# Altium schematic sheet MCU Main.SchDoc
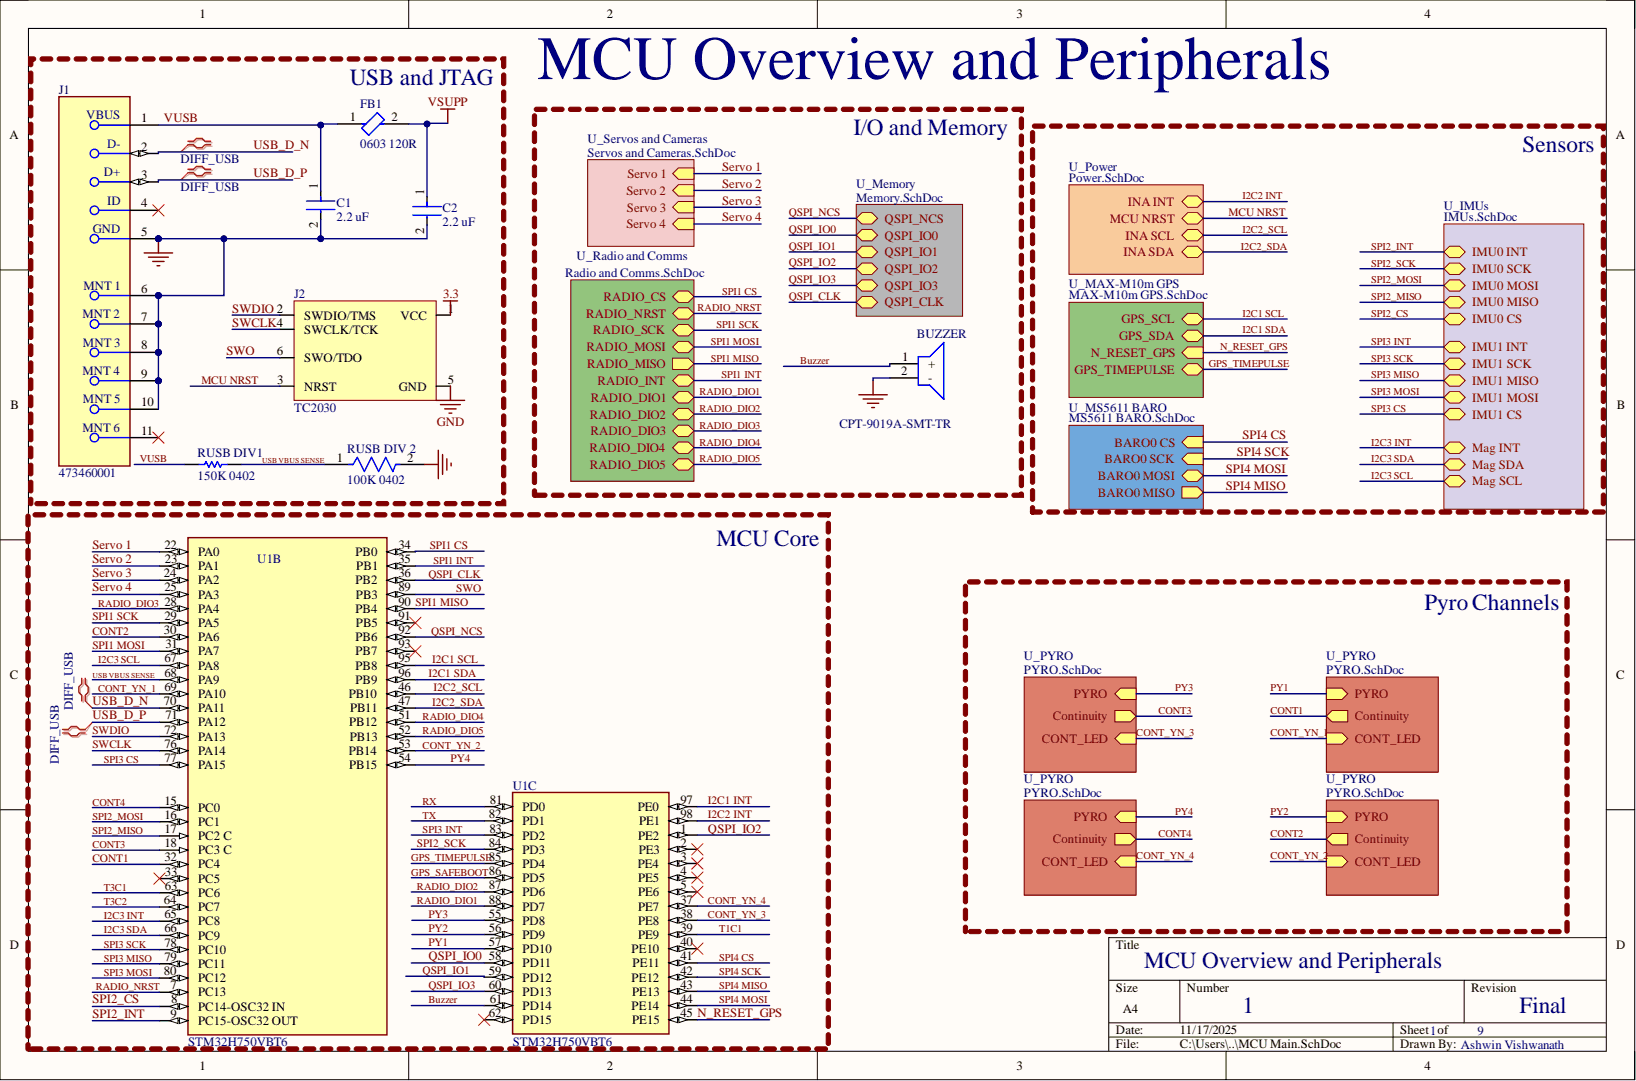
[diagram p0: MCU Main.SchDoc]

## Parts
BUZZER  CPT-9019A-SMT-TR  [CPT9019ASMTTR]
C1  2.2 uF  [FP-CL10-IPC_A]
C2  2.2 uF  [FP-CL10-IPC_A]
FB1  0603 120R  Case Code (Imperial): 0603  Impedance: 120R  [FP-MPZ1608-0_6-IPC_A]
J1  473460001  [FP-473460001-MFG]
J2  TC2030  [TC2030-FP]  (no BOM)
RUSB_DIV1  150K 0402  [RESC1005X40X25NL10T10]
RUSB_DIV_2  100K 0402  [FP-CRGP0402-IPC_C]
U1  STM32H750VBT6  [FP-LQFP100-1L-MFG]  (parts B,C)

## Nets
3.3: J2.1(VCC)
GND: BUZZER.2 C1.2 C2.2 J1.5 J1.6 J1.7 J1.8 J1.9 J1.10 J2.5(GND) RUSB_DIV_2.2
VSUPP: C2.1 FB1.2
Buzzer: BUZZER.1 U1.61(PD14)
CONT1: U1.32(PC4)
CONT2: U1.30(PA6)
CONT3: U1.18(PC3_C)
CONT4: U1.15(PC0)
CONT_YN_1: U1.69(PA10)
CONT_YN_2: U1.53(PB14)
CONT_YN_3: U1.38(PE8)
CONT_YN_4: U1.37(PE7)
GPS_SAFEBOOT: U1.86(PD5)
GPS_TIMEPULSE: U1.85(PD4)
I2C1 INT: U1.97(PE0)
I2C1 SCL: U1.95(PB8)
I2C1 SDA: U1.96(PB9)
I2C2 INT: U1.98(PE1)
I2C2_SCL: U1.46(PB10)
I2C2_SDA: U1.47(PB11)
I2C3 INT: U1.65(PC8)
I2C3 SCL: U1.67(PA8)
I2C3 SDA: U1.66(PC9)
MCU NRST: J2.3(NRST)
N_RESET_GPS: U1.45(PE15)
PY1: U1.57(PD10)
PY2: U1.56(PD9)
PY3: U1.55(PD8)
PY4: U1.54(PB15)
QSPI_CLK: U1.36(PB2)
QSPI_IO0: U1.58(PD11)
QSPI_IO1: U1.59(PD12)
QSPI_IO2: U1.1(PE2)
QSPI_IO3: U1.60(PD13)
QSPI_NCS: U1.92(PB6)
RADIO_DIO1: U1.88(PD7)
RADIO_DIO2: U1.87(PD6)
RADIO_DIO3: U1.28(PA4)
RADIO_DIO4: U1.51(PB12)
RADIO_DIO5: U1.52(PB13)
RADIO_NRST: U1.7(PC13)
RX: U1.81(PD0)
Servo 1: U1.22(PA0)
Servo 2: U1.23(PA1)
Servo 3: U1.24(PA2)
Servo 4: U1.25(PA3)
SPI1 CS: U1.34(PB0)
SPI1 INT: U1.35(PB1)
SPI1 MISO: U1.90(PB4)
SPI1 MOSI: U1.31(PA7)
SPI1 SCK: U1.29(PA5)
SPI2_CS: U1.8(PC14-OSC32_IN)
SPI2_INT: U1.9(PC15-OSC32_OUT)
SPI2_MISO: U1.17(PC2_C)
SPI2_MOSI: U1.16(PC1)
SPI2_SCK: U1.84(PD3)
SPI3 CS: U1.77(PA15)
SPI3 INT: U1.83(PD2)
SPI3 MISO: U1.79(PC11)
SPI3 MOSI: U1.80(PC12)
SPI3 SCK: U1.78(PC10)
SPI4 CS: U1.41(PE11)
SPI4 MISO: U1.43(PE13)
SPI4 MOSI: U1.44(PE14)
SPI4 SCK: U1.42(PE12)
SWCLK: J2.4(SWCLK/TCK) U1.76(PA14)
SWDIO: J2.2(SWDIO/TMS) U1.72(PA13)
SWO: J2.6(SWO/TDO) U1.89(PB3)
T1C1: U1.39(PE9)
T3C1: U1.63(PC6)
T3C2: U1.64(PC7)
TX: U1.82(PD1)
USB VBUS SENSE: RUSB_DIV1.2 RUSB_DIV_2.1 U1.68(PA9)
USB_D_N: J1.2 U1.70(PA11)
USB_D_P: J1.3 U1.71(PA12)
VUSB: C1.1 FB1.1 J1.1 RUSB_DIV1.1
NetJ1_4: J1.4
NetJ1_11: J1.11
NetU1_2: U1.2(PE3)
NetU1_3: U1.3(PE4)
NetU1_4: U1.4(PE5)
NetU1_5: U1.5(PE6)
NetU1_33: U1.33(PC5)
NetU1_40: U1.40(PE10)
NetU1_62: U1.62(PD15)
NetU1_91: U1.91(PB5)
NetU1_93: U1.93(PB7)

## Library data of the parts
BUZZER = CPT-9019A-SMT-TR: Loudspeaker or Buzzer; Manufacturer_Name: Same Sky; Arrow Part Number: CPT-9019A-SMT-TR; Arrow Price/Stock: https://www.arrow.com/en/products/cpt-9019a-smt-tr/cui-devices?utm_currency=USD&region=nac; Component Kind: Standard; Datasheet Link: https://www.sameskydevices.com/product/resource/supplyframepdf/cpt-9019a-smt-tr.pdf; Height: 2.1mm; Manufacturer_Part_Number: CPT-9019A-SMT-TR; Mouser Part Number: 179-CPT-9019A-SMT-TR; Mouser Price/Stock: https://www.mouser.co.uk/ProductDetail/Same-Sky/CPT-9019A-SMT-TR?qs=3Rah4i%252BhyCH9W9p08uaaRg%3D%3D
C1 C2 = CMP-13271-000835-1: Cap Ceramic 2.2uF 16V X5R ±10% Pad SMD 0603 +85°C T/R; Capacitance: 2.2uF; Case Code (Imperial): 0603; Case Code (Metric): 1608; Case/Package: 0603; Component Kind: Standard; Contact Plating: Tin; Depth: 0.8mm; Dielectric: X5R; Height: 0.8mm; Lead Free: Lead Free; Length: 1.6mm; Library Name: Altium Content Vault; Material: Ceramic; Max Operating Temperature: 85°C; Min Operating Temperature: -55°C; Mount: Surface Mount; Package Quantity: 4000; Packaging: Tape and Reel; Radiation Hardening: No; Thickness: 0.035inch; Tolerance: 10%; Voltage: 16V; Voltage Rating: 16V; Voltage Rating (DC): 16V; Width: 0.031inch
FB1 = CMP-08257-000040-1: FERRITE BEAD 120 OHM 0603 1LN; Current Rating: 2A; DC Resistance (DCR): 45mR; Tolerance: 25%; Case Code (Metric): 1608; Case/Package: 0603; Component Kind: Standard; Core Material: Ferrite; Depth: 0.8mm; Height: 0.03inch; Length: 0.063inch; Library Name: Altium Content Vault; Max DC Current: 2A; Mount: Surface Mount; Package Quantity: 4000; Packaging: Tape and Reel; RoHS Compliant: Yes; Width: 0.031inch
J1 = CMP-2000-05246-2: CONN RCPT USB2.0 MICRO B SMD R/A; Manufacturer: Molex; Conn Orientation: Right Angle; Current Rating: 1.8A; Is Female: yes; Number Of Contacts: 5; Pitch: 0.65mm; Shielding: true; Voltage Rating: 30V; Automotive: No; Category: Conn; Component Kind: Standard; ComponentLink2Description: Datasheet; ComponentLink2URL: https://www.molex.com/webdocs/datasheets/pdf/en-us/0473460001_IO_CONNECTORS.pdf; Contact Material: Gold,Nickel; Device Class L1: Connectors; Device Class L2: USB Connectors; Device Class L3: unset; Digikey Description: CONN RCPT USB2.0 MICRO B SMD R/A; Footprint Url: https://www.molex.com/pdm_docs/sd/473460001_sd.pdf; Height: 2.945mm; Lead Free: Yes; Library Name: Altium Content Vault; Manufacturer Part Number: 473460001; Octopart: https://octopart.com/47346-0001-molex-7164928; Package: 0; RoHS: TRUE; Temperature: -30°C to +85°C; Temperature Range High: +85°C; Temperature Range Low: -30°C; Usb Standard: USB 2.0
J2 = CMP-002-00075-1: Tag-Connect No-Solder SWD Debug Connector DO NOT PLACE; Design Item ID: TC2030; Library Name: C.A.S.P.E.R; Manufacturer: Tag-Connect; Manufacturer Part Number: TC2030; Source: Schematic-Lib-PhilsLab.SchLib
RUSB_DIV1 = CMP-2002-05692-1: Manufacturer: TE Connectivity / AMP; Part Number: CRG0402F150K; Component Kind: Standard; Library Name: Altium Content Vault
RUSB_DIV_2 = CMP-03211-001392-1: SMD Precision Pulse Thick Film Chip Resistor; Manufacturer: TE; Manufacturer Part Number: CRGP0402F100K; Power Rating: 0.125W; Resistance: 100KΩ; Temperature Coefficient: ±100ppm/°C; Tolerance: 0.01; Value: 100KΩ; Voltage Rating: 50V; Automotive: No; Category: Res; Component Kind: Standard; ComponentLink2Description: Datasheet; ComponentLink2URL: https://www.mouser.ca/datasheet/2/418/NG_DS_9-1773463-9_A-1358566.pdf; Composition: Thick Film; Device Class L1: Passive Components; Device Class L2: Resistors; Device Class L3: Chip SMD Resistors; Digikey Description: SMD Precision Pulse Thick Film Chip Resistor; Height: 0.4mm; Ipc Land Pattern Name: RESC11050X35; Lead Free: Yes; Library Name: Altium Content Vault; Octopart: https://octopart.com/crgp0402f100k-te+connectivity-91018658; Package: CRGP0402; RoHS: TRUE; Series: CRGP; Temperature: -55°C to +155°C; Temperature Range High: +155°C; Temperature Range Low: -55°C
U1 = CMP-12105-000017-1: IC MCU 32BIT 128KB FLASH 100LQFP; Manufacturer: STMicroelectronics; Core Architecture: ARM Cortex-M7; Data Bus Width: 32bits; Frequency: 480MHz; Interface: I2C,SPI,UART,USART,CAN,USB,LIN,IrDA,Ethernet,I2S,Other; Max Junction Temp: +125°C; Max Supply Voltage: 3.6V; Memory Size: 128KBytes; Min Supply Voltage: 1.62V; Number Of A/D Converters: 3; Number Of Adc Channels: 36; Number Of Cores: 1; Number Of I2C Channels: 4; Number Of I/Os: 82; Number Of Pwm Channels: 42; Number Of Spi Channels: 6; Number Of Timers/Counters: 20; Number Of Uart Channels: 9; Number Of Usb Channels: 2; Ram Size: 1MBytes; Standoff Height: 0.05mm; Ambient Temperature Range High: +85°C; Ambient Temperature Range Low: -40°C; Automotive: No; Category: IC; Component Kind: Standard; ComponentLink2Description: Datasheet; ComponentLink2URL: https://www.st.com/resource/en/datasheet/stm32h750vb.pdf; Device Class L1: Integrated Circuits (ICs); Device Class L2: Embedded Processors and Controllers; Device Class L3: Microcontrollers; Digikey Description: IC MCU 32BIT 128KB FLASH 100LQFP; Height: 1.6mm; Lead Free: Yes; Library Name: Altium Content Vault; Manufacturer Part Number: STM32H750VBT6; Memory Type: FLASH; Mouser Description: MCU 32-Bit STM32H750VB ARM Cortex M7 RISC 128KB Flash 1.8V/2.5V/3.3V 100-Pin LQFP Tray; Number Of Ethernet Channels: 1; Octopart: https://octopart.com/stm32h750vbt6-stmicroelectronics-94048547; Package: LQFP100-1L; RoHS: TRUE; Temperature: -40°C to +125°C; Temperature Range High: +125°C; Temperature Range Low: -40°C
